# Altium schematic sheet joystick.SchDoc(joystick)
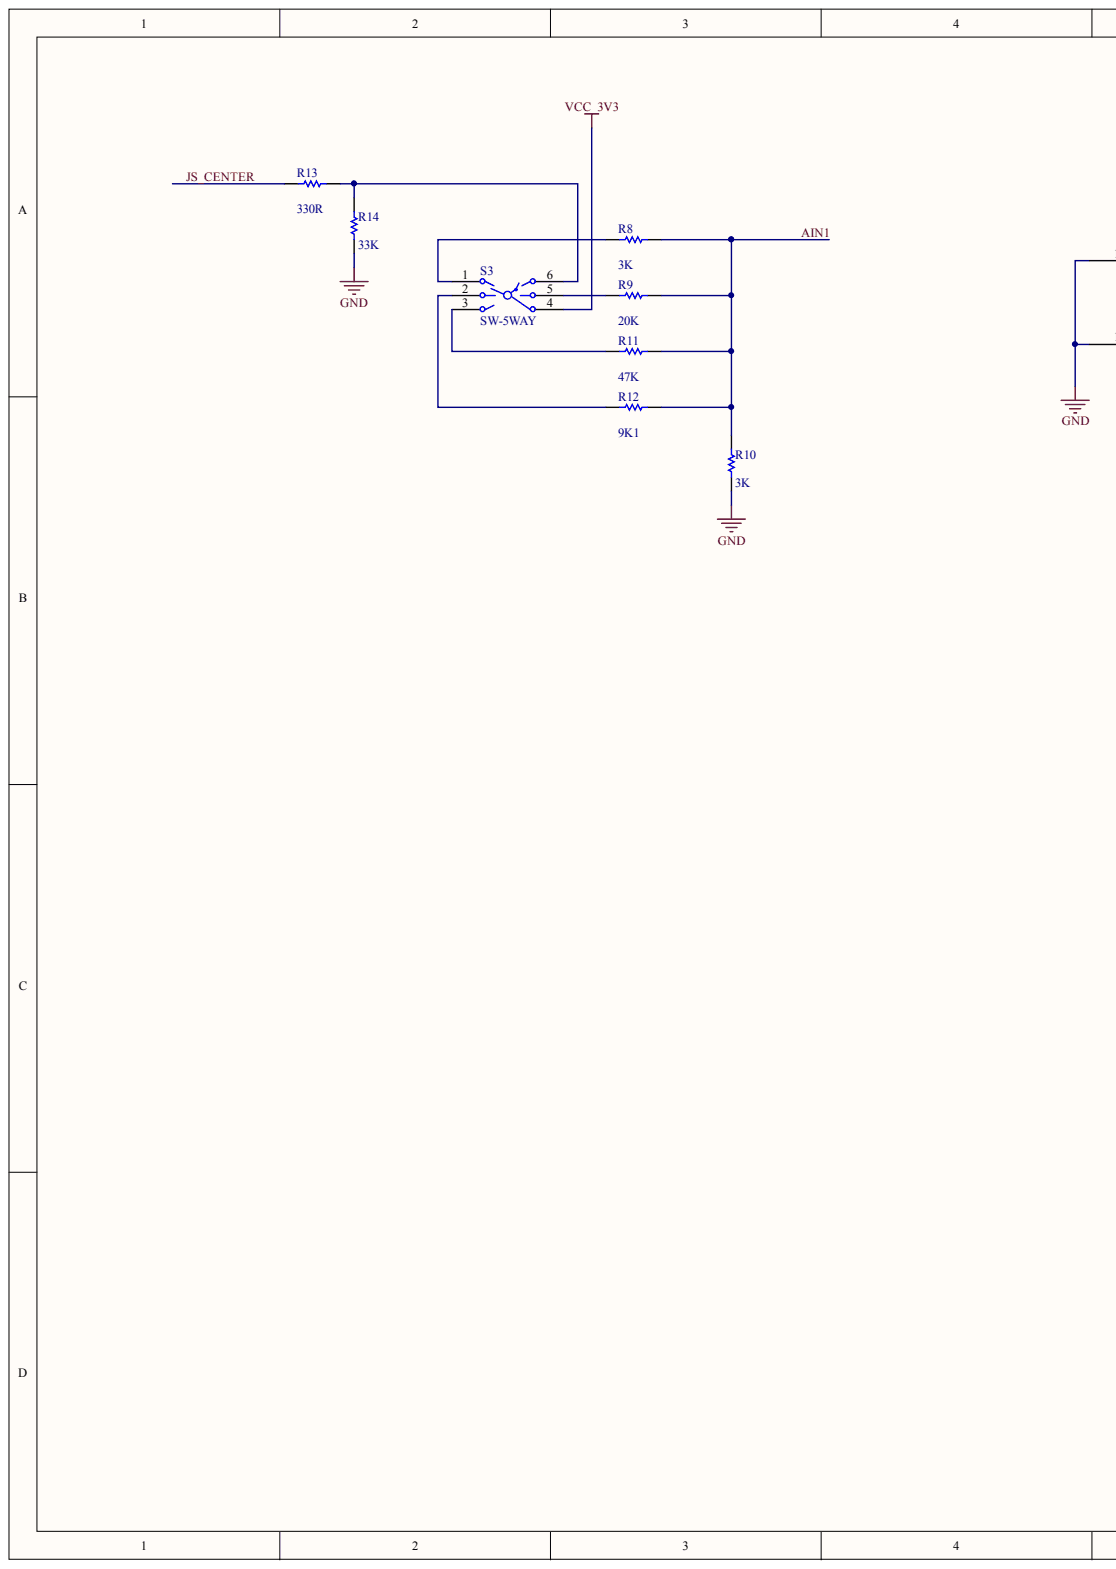
[diagram p0: joystick.SchDoc, left]
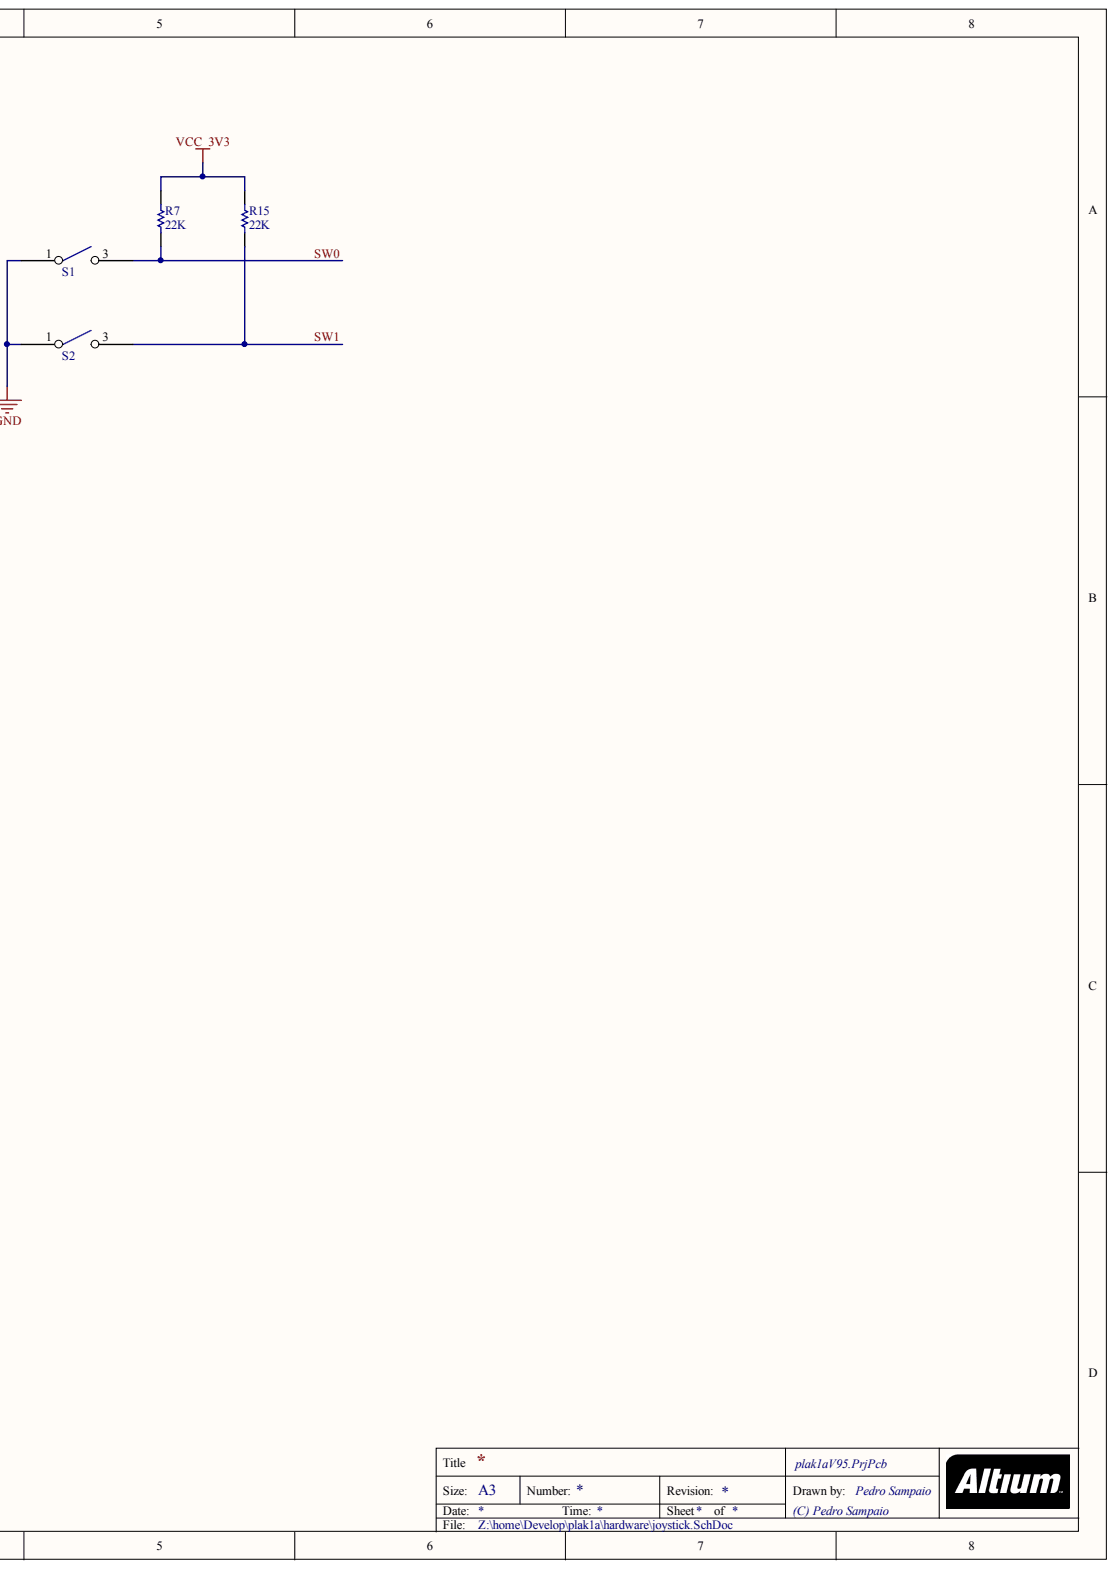
[diagram p1: joystick.SchDoc, right]

## Parts
R7  value 22K  [3216[1206]]  (hidden comment: Res Semi)
R8  value 3K  [3216[1206]]  (hidden comment: Res Semi)
R9  value 20K  [3216[1206]]  (hidden comment: Res Semi)
R10  value 3K  [3216[1206]]  (hidden comment: Res Semi)
R11  value 47K  [3216[1206]]  (hidden comment: Res Semi)
R12  value 9K1  [3216[1206]]  (hidden comment: Res Semi)
R13  value 330R  [3216[1206]]  (hidden comment: Res Semi)
R14  value 33K  [3216[1206]]  (hidden comment: Res Semi)
R15  value 22K  [3216[1206]]  (hidden comment: Res Semi)
S1  Switch SMD  [B3FS]  (value not drawn)
S2  Switch SMD  [B3FS]  (value not drawn)
S3  SW-5WAY  [Nav-SW]

## Nets
GND: R10.1 R14.1 S1.1 S2.1
VCC_3V3: R7.2 R15.2 S3.4
AIN1: R8.2 R9.2 R10.2 R11.2 R12.2
JS_CENTER: R13.1
SW0: R7.1 S1.3
SW1: R15.1 S2.3
NetR8_1: R8.1 S3.1
NetR9_1: R9.1 S3.5
NetR11_1: R11.1 S3.3
NetR12_1: R12.1 S3.2
NetR13_2: R13.2 R14.2 S3.6

## Library data of the parts
R7 R10 R12-R15 = Res Semi: Semiconductor Resistor; Component Kind: Standard; LatestRevisionDate: 17-Jul-2002; LatestRevisionNote: Re-released for DXP Platform.; Library Name: Miscellaneous Devices.IntLib; PackageReference: AXIAL-0.5; Published: 8-Jun-2000; Signal Integrity: Res Semi; Simulation: RES; Publisher: Altium Limited
  R7 R15: Farnell: 1469995
  R10: Farnell: 9336311
  R12: Farnell: 1469984
  R13: Farnell: 1527413
  R14: Farnell: 9241035
R8 = Res Semi: Semiconductor Resistor; Value: 3K; Component Kind: Standard; Farnell: 9336311; LatestRevisionDate: 17-Jul-2002; LatestRevisionNote: Re-released for DXP Platform.; Library Name: Miscellaneous Devices.IntLib; PackageReference: AXIAL-0.5; Published: 8-Jun-2000; Signal Integrity: Res Semi; Simulation: RES; Publisher: Altium Limited
R9 = Res Semi: Semiconductor Resistor; Value: 20K; Component Kind: Standard; Farnell: 1469993; LatestRevisionDate: 17-Jul-2002; LatestRevisionNote: Re-released for DXP Platform.; Library Name: Miscellaneous Devices.IntLib; PackageReference: AXIAL-0.5; Published: 8-Jun-2000; Signal Integrity: Res Semi; Simulation: RES; Publisher: Altium Limited
R11 = Res Semi: Semiconductor Resistor; Value: 47K; Component Kind: Standard; Farnell: 9240721; LatestRevisionDate: 17-Jul-2002; LatestRevisionNote: Re-released for DXP Platform.; Library Name: Miscellaneous Devices.IntLib; PackageReference: AXIAL-0.5; Published: 8-Jun-2000; Signal Integrity: Res Semi; Simulation: RES; Publisher: Altium Limited
S1 S2 = B3FS: Tactile Switch 6x6mm; Component Kind: Standard; Farnell: 1181016; Library Name: Componentes.IntLib
S3 = SW-5WAY: 6 Way Switch; Component Kind: Standard; LatestRevisionDate: 17-Jul-2002; LatestRevisionNote: Re-released for DXP Platform.; Library Name: Componentes.IntLib; PackageReference: SW-7; Published: 24-Mar-1999; Publisher: Altium Limited
